# Altium schematic sheet drvinput6.SchDoc(drvinput6)
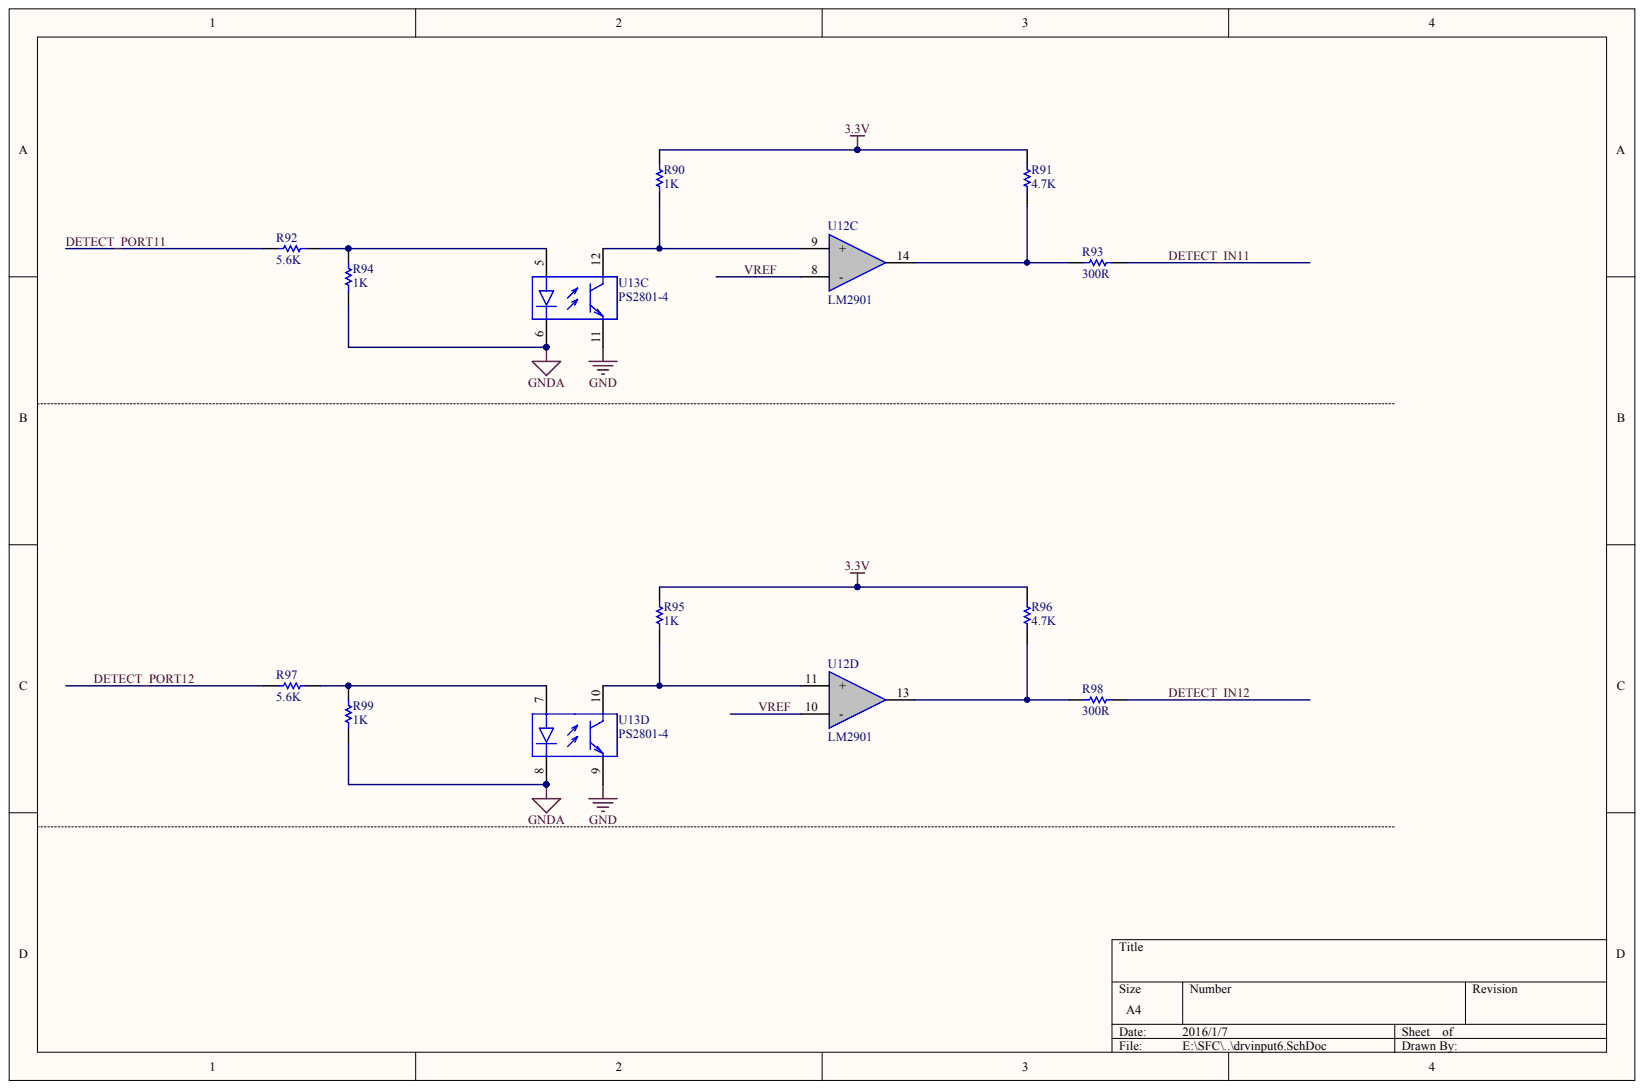
[diagram p0: drvinput6.SchDoc]

## Parts
R90  1K
R91  4.7K
R92  5.6K
R93  300R
R94  1K
R95  1K
R96  4.7K
R97  5.6K
R98  300R
R99  1K
U12  LM2901  (parts C,D)
U13  PS2801-4  (parts C,D)

## Nets
3.3V: R90.1 R91.2 R95.1 R96.2
GND: U13.9 U13.11
GNDA: R94.1 R99.1 U13.6 U13.8
DETECT_IN11: R93.1
DETECT_IN12: R98.2
DETECT_PORT11: R92.2
DETECT_PORT12: R97.2
VREF: U12.8(-) U12.10(-)
NetR90_2: R90.2 U12.9(+) U13.12
NetR91_1: R91.1 R93.2 U12.14
NetR92_1: R92.1 R94.2 U13.5
NetR95_2: R95.2 U12.11(+) U13.10
NetR96_1: R96.1 R98.1 U12.13
NetR97_1: R97.1 R99.2 U13.7

## Library data of the parts
R90-R97 R99 = (no symbol name): Value: 10K; Published: 8-Jun-2000; LatestRevisionDate: 17-Jul-2002; LatestRevisionNote: Re-released for DXP Platform.; PackageReference: AXIAL-0.3; Publisher: Altium Limited
R98 = (no symbol name): Value: 470; Published: 8-Jun-2000; LatestRevisionDate: 17-Jul-2002; LatestRevisionNote: Re-released for DXP Platform.; PackageReference: AXIAL-0.3; Publisher: Altium Limited
U12 U13 = (no symbol name)
  U13: Published: 28-Feb-2003; LatestRevisionDate: 13-Apr-2006; LatestRevisionNote: IPC-7351 Footprint Added.; DatasheetVersion: Jan-2002, Rev. 7; PackageVersion: rev7, Jan-2002; PackageReference: 751-07; PackageDescription: 8-Pin Plastic Small Outline Integrated Circuit 1.27 mm Pitch; Code_JEDEC: MS-012-AA; Code_IPC: SOIC127P600-8; ComponentLink1Description: Manufacturer Link; ComponentLink1URL: http://www.onsemi.com/; ComponentLink2Description: Datasheet; ComponentLink2URL: http://www.onsemi.com/pub/Collateral/LM358-D.PDF; Publisher: Altium Limited
